# Altium schematic sheet Sheet1.SchDoc(Sheet1)
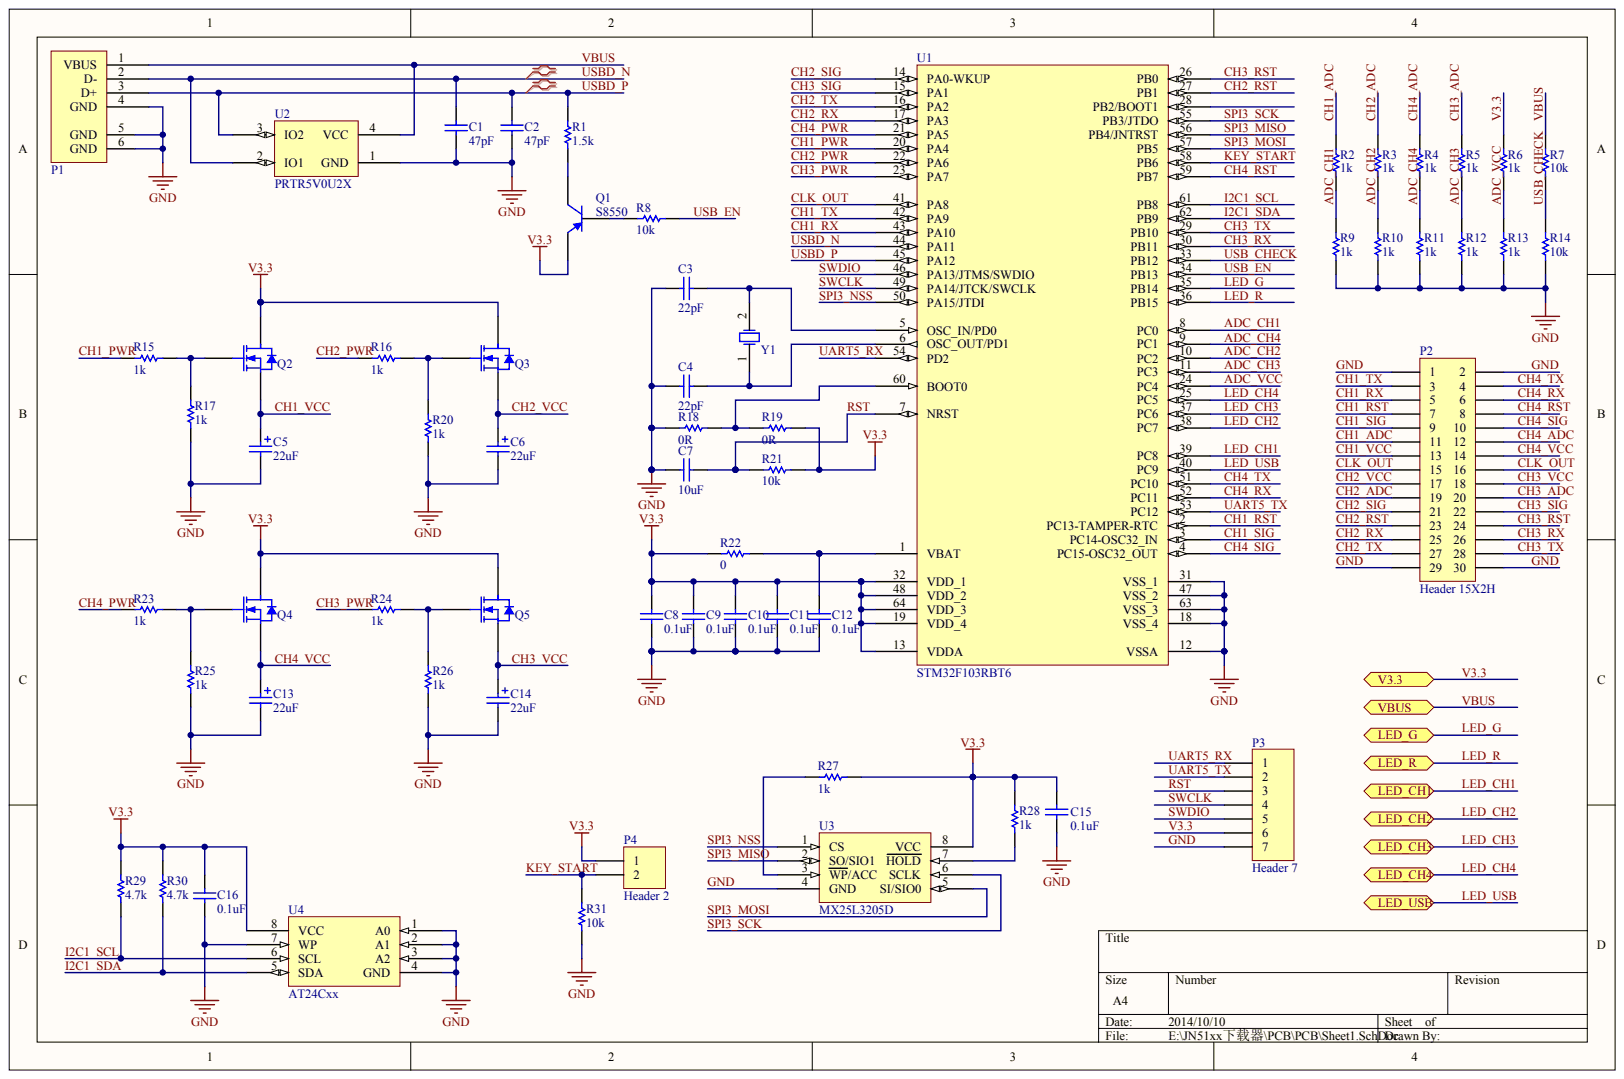
[diagram p0: Sheet1.SchDoc]

## Parts
C1  value 47pF  (hidden comment: Cap)
C2  value 47pF  (hidden comment: Cap)
C3  value 22pF  (hidden comment: Cap)
C4  value 22pF  (hidden comment: Cap)
C5  value 22uF  (hidden comment: Cap)
C6  value 22uF  (hidden comment: Cap)
C7  value 10uF  (hidden comment: Cap)
C8  value 0.1uF  (hidden comment: Cap)
C9  value 0.1uF  (hidden comment: Cap)
C10  value 0.1uF  (hidden comment: Cap)
C11  value 0.1uF  (hidden comment: Cap)
C12  value 0.1uF  (hidden comment: Cap)
C13  value 22uF  (hidden comment: Cap)
C14  value 22uF  (hidden comment: Cap)
C15  value 0.1uF  (hidden comment: Cap)
C16  value 0.1uF  (hidden comment: Cap)
P1  USB  (value not drawn)
P2  Header 15X2H
P3  Header 7
P4  Header 2
Q1  S8550
Q2  N-MOS  (value not drawn)
Q3  N-MOS  (value not drawn)
Q4  N-MOS  (value not drawn)
Q5  N-MOS  (value not drawn)
R1  value 1.5k  (hidden comment: Res)
R2  value 1k  (hidden comment: Res)
R3  value 1k  (hidden comment: Res)
R4  value 1k  (hidden comment: Res)
R5  value 1k  (hidden comment: Res)
R6  value 1k  (hidden comment: Res)
R7  value 10k  (hidden comment: Res)
R8  value 10k  (hidden comment: Res)
R9  value 1k  (hidden comment: Res)
R10  value 1k  (hidden comment: Res)
R11  value 1k  (hidden comment: Res)
R12  value 1k  (hidden comment: Res)
R13  value 1k  (hidden comment: Res)
R14  value 10k  (hidden comment: Res)
R15  value 1k  (hidden comment: Res)
R16  value 1k  (hidden comment: Res)
R17  value 1k  (hidden comment: Res)
R18  value 0R  (hidden comment: Res)
R19  value 0R  (hidden comment: Res)
R20  value 1k  (hidden comment: Res)
R21  value 10k  (hidden comment: Res)
R22  value 0  (hidden comment: Res)
R23  value 1k  (hidden comment: Res)
R24  value 1k  (hidden comment: Res)
R25  value 1k  (hidden comment: Res)
R26  value 1k  (hidden comment: Res)
R27  value 1k  (hidden comment: Res)
R28  value 1k  (hidden comment: Res)
R29  value 4.7k  (hidden comment: Res)
R30  value 4.7k  (hidden comment: Res)
R31  value 10k  (hidden comment: Res)
U1  STM32F103RBT6
U2  PRTR5V0U2X
U3  MX25L3205D
U4  AT24Cxx
Y1  XTAL2  (value not drawn)

## Ports
LED_CH1, LED_CH2, LED_CH3, LED_CH4, LED_G, LED_R, LED_USB, V3.3, VBUS

## Nets
ADC_CH1: R2.1 R9.2 U1.8(PC0)
ADC_CH2: R3.1 R10.2 U1.10(PC2)
ADC_CH3: R5.1 R12.2 U1.11(PC3)
ADC_CH4: R4.1 R11.2 U1.9(PC1)
ADC_VCC: R6.1 R13.2 U1.24(PC4)
CH1_ADC: P2.11 R2.2
CH1_PWR: R15.1 U1.20(PA4)
CH1_RST: P2.7 U1.2(PC13-TAMPER-RTC)
CH1_RX: P2.5 U1.43(PA10)
CH1_SIG: P2.9 U1.3(PC14-OSC32_IN)
CH1_TX: P2.3 U1.42(PA9)
CH1_VCC: C5.1 P2.13 Q2.2
CH2_ADC: P2.19 R3.2
CH2_PWR: R16.2 U1.22(PA6)
CH2_RST: P2.23 U1.27(PB1)
CH2_RX: P2.25 U1.17(PA3)
CH2_SIG: P2.21 U1.14(PA0-WKUP)
CH2_TX: P2.27 U1.16(PA2)
CH2_VCC: C6.1 P2.17 Q3.2
CH3_ADC: P2.20 R5.2
CH3_PWR: R24.2 U1.23(PA7)
CH3_RST: P2.24 U1.26(PB0)
CH3_RX: P2.26 U1.30(PB11)
CH3_SIG: P2.22 U1.15(PA1)
CH3_TX: P2.28 U1.29(PB10)
CH3_VCC: C14.1 P2.18 Q5.2
CH4_ADC: P2.12 R4.2
CH4_PWR: R23.1 U1.21(PA5)
CH4_RST: P2.8 U1.59(PB7)
CH4_RX: P2.6 U1.52(PC11)
CH4_SIG: P2.10 U1.4(PC15-OSC32_OUT)
CH4_TX: P2.4 U1.51(PC10)
CH4_VCC: C13.1 P2.14 Q4.2
CLK_OUT: P2.15 P2.16 U1.41(PA8)
GND: C1.1 C2.1 C3.1 C4.2 C5.2 C6.2 C7.2 C8.1 C9.1 C10.1 C11.1 C12.1 C13.2 C14.2 C15.1 C16.1 P1.4(GND) P1.5(GND) P1.6(GND) P2.1 P2.2 P2.29 P2.30 P3.7 R9.1 R10.1 R11.1 R12.1 R13.1 R14.1 R17.1 R18.1 R20.2 R25.1 R26.2 R31.1 U1.12(VSSA) U1.18(VSS_4) U1.31(VSS_1) U1.47(VSS_2) U1.63(VSS_3) U2.1(GND) U3.4(GND) U4.1(A0) U4.2(A1) U4.3(A2) U4.4(GND) U4.7(WP)
I2C1_SCL: R29.1 U1.61(PB8) U4.6(SCL)
I2C1_SDA: R30.1 U1.62(PB9) U4.5(SDA)
KEY_START: P4.2 R31.2 U1.58(PB6)
LED_CH1: U1.39(PC8) ; port LED_CH1
LED_CH2: U1.38(PC7) ; port LED_CH2
LED_CH3: U1.37(PC6) ; port LED_CH3
LED_CH4: U1.25(PC5) ; port LED_CH4
LED_G: U1.35(PB14) ; port LED_G
LED_R: U1.36(PB15) ; port LED_R
LED_USB: U1.40(PC9) ; port LED_USB
RST: C7.1 P3.3 R21.2 U1.7(NRST)
SPI3_MISO: U1.56(PB4/JNTRST) U3.2(SO/SIO1)
SPI3_MOSI: U1.57(PB5) U3.5(SI/SIO0)
SPI3_NSS: U1.50(PA15/JTDI) U3.1(CS)
SPI3_SCK: U1.55(PB3/JTDO) U3.6(SCLK)
SWCLK: P3.4 U1.49(PA14/JTCK/SWCLK)
SWDIO: P3.5 U1.46(PA13/JTMS/SWDIO)
UART5_RX: P3.1 U1.54(PD2)
UART5_TX: P3.2 U1.53(PC12)
USB_CHECK: R7.1 R14.2 U1.33(PB12)
USB_EN: R8.2 U1.34(PB13)
USBD_N: C1.2 P1.2(D-) U1.44(PA11) U2.2(IO1)
USBD_P: C2.2 P1.3(D+) R1.2 U1.45(PA12) U2.3(IO2)
V3.3: C8.2 C9.2 C10.2 C11.2 C15.2 C16.2 P3.6 P4.1 Q1.2 Q2.3 Q3.3 Q4.3 Q5.3 R6.2 R19.2 R21.1 R22.1 R27.2 R28.2 R29.2 R30.2 U1.13(VDDA) U1.19(VDD_4) U1.32(VDD_1) U1.48(VDD_2) U1.64(VDD_3) U3.8(VCC) U4.8(VCC) ; port V3.3
VBUS: P1.1(VBUS) R7.2 U2.4(VCC) ; port VBUS
NetC3_2: C3.2 U1.5(OSC_IN/PD0) Y1.2
NetC4_1: C4.1 U1.6(OSC_OUT/PD1) Y1.1
NetC12_2: C12.2 R22.2 U1.1(VBAT)
NetQ1_1: Q1.1 R8.1
NetQ1_3: Q1.3 R1.1
NetQ2_1: Q2.1 R15.2 R17.2
NetQ3_1: Q3.1 R16.1 R20.1
NetQ4_1: Q4.1 R23.2 R25.2
NetQ5_1: Q5.1 R24.1 R26.1
NetR18_2: R18.2 R19.1 U1.60(BOOT0)
NetR27_1: R27.1 U3.3(WP/ACC)
NetR28_1: R28.1 U3.7(HOLD)

Not connected: U1 28(PB2/BOOT1)

## Library data of the parts
Y1 = (no symbol name): Value: 12MHz
C1-C16 P1-P4 Q1-Q5 R1-R31 U1-U4 = (no symbol name)
  P2-P4: LatestRevisionDate: 17-Jul-2002; LatestRevisionNote: Re-released for DXP Platform.
  U1: Published: 30-May-2008; DatasheetVersion: rev6, Mar-2008; PackageVersion: Mar-2008; PackageReference: LQFP64; PackageDescription: 64-Pin Low-Profile Quad Flat Pack, 10 x 10 mm Body, 0.5 mm Pitch (LQFP64); ComponentLink1Description: Manufacturer Link; ComponentLink1URL: www.st.com/stm32; ComponentLink2Description: Datasheet; ComponentLink2URL: http://www.st.com/stonline/products/literature/ds/13587.pdf
  P2-P4 U1: Publisher: Altium Limited
